# Altium schematic sheet Sheet1.SchDoc
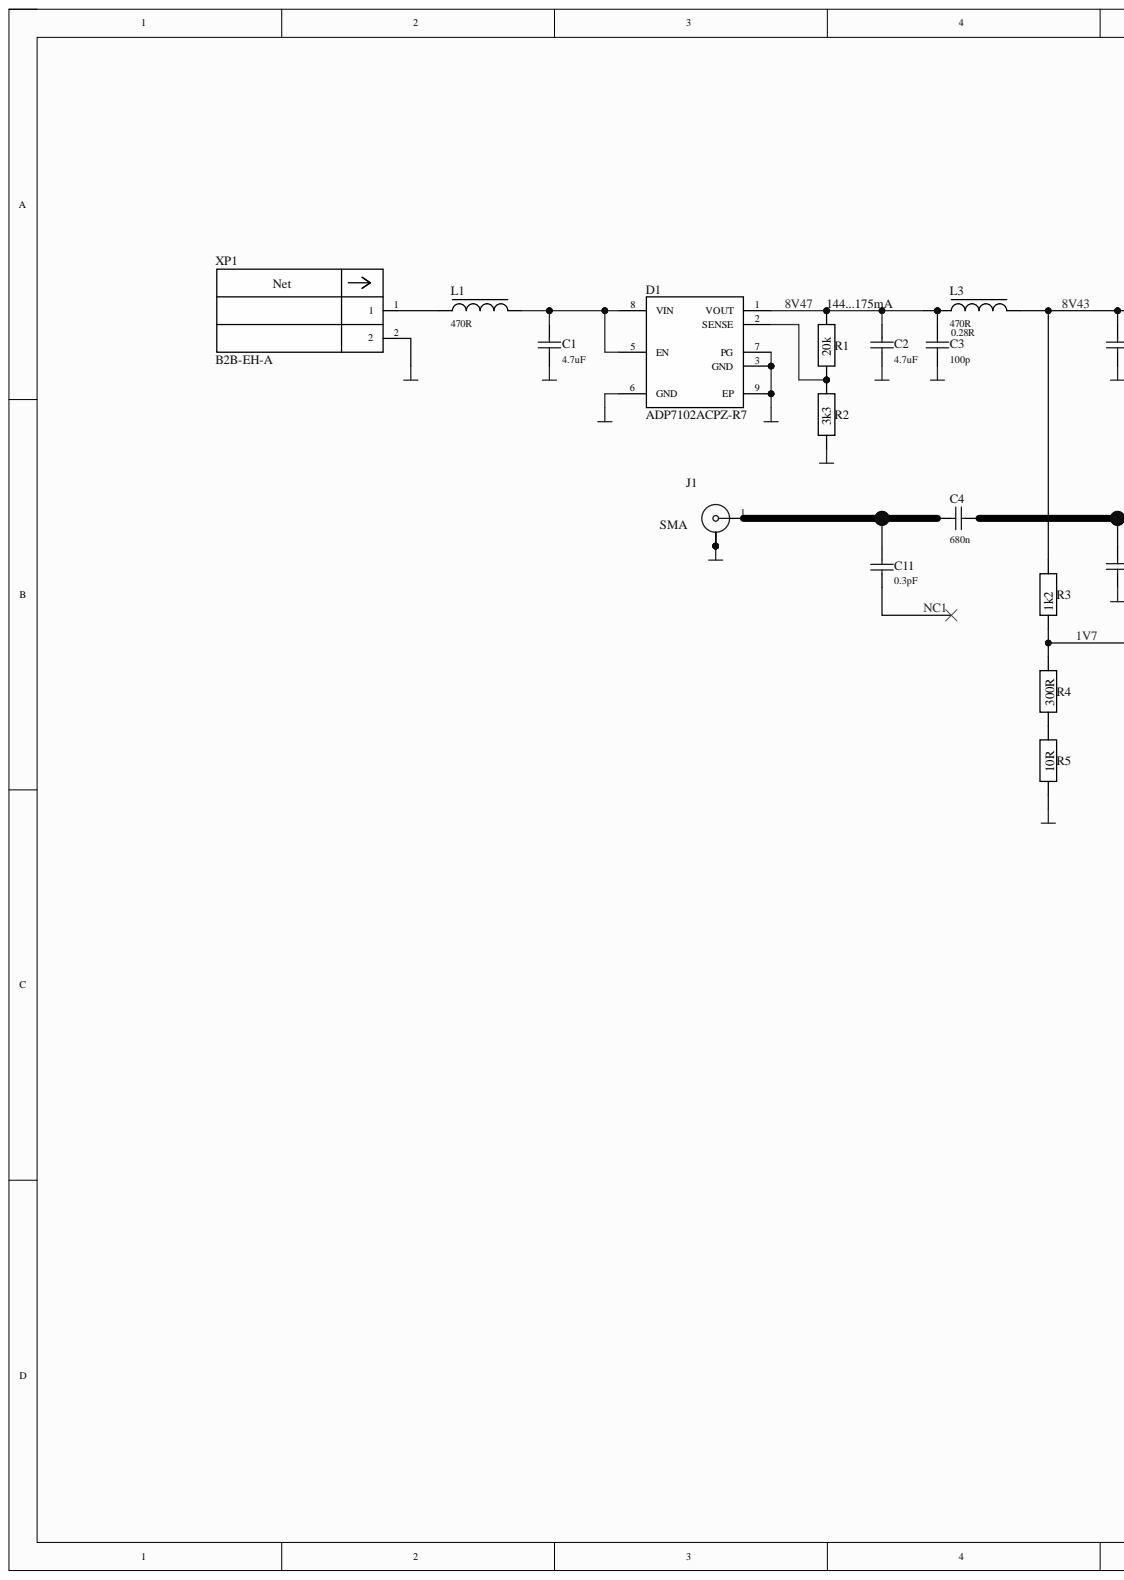
[diagram p0: Sheet1.SchDoc, left]
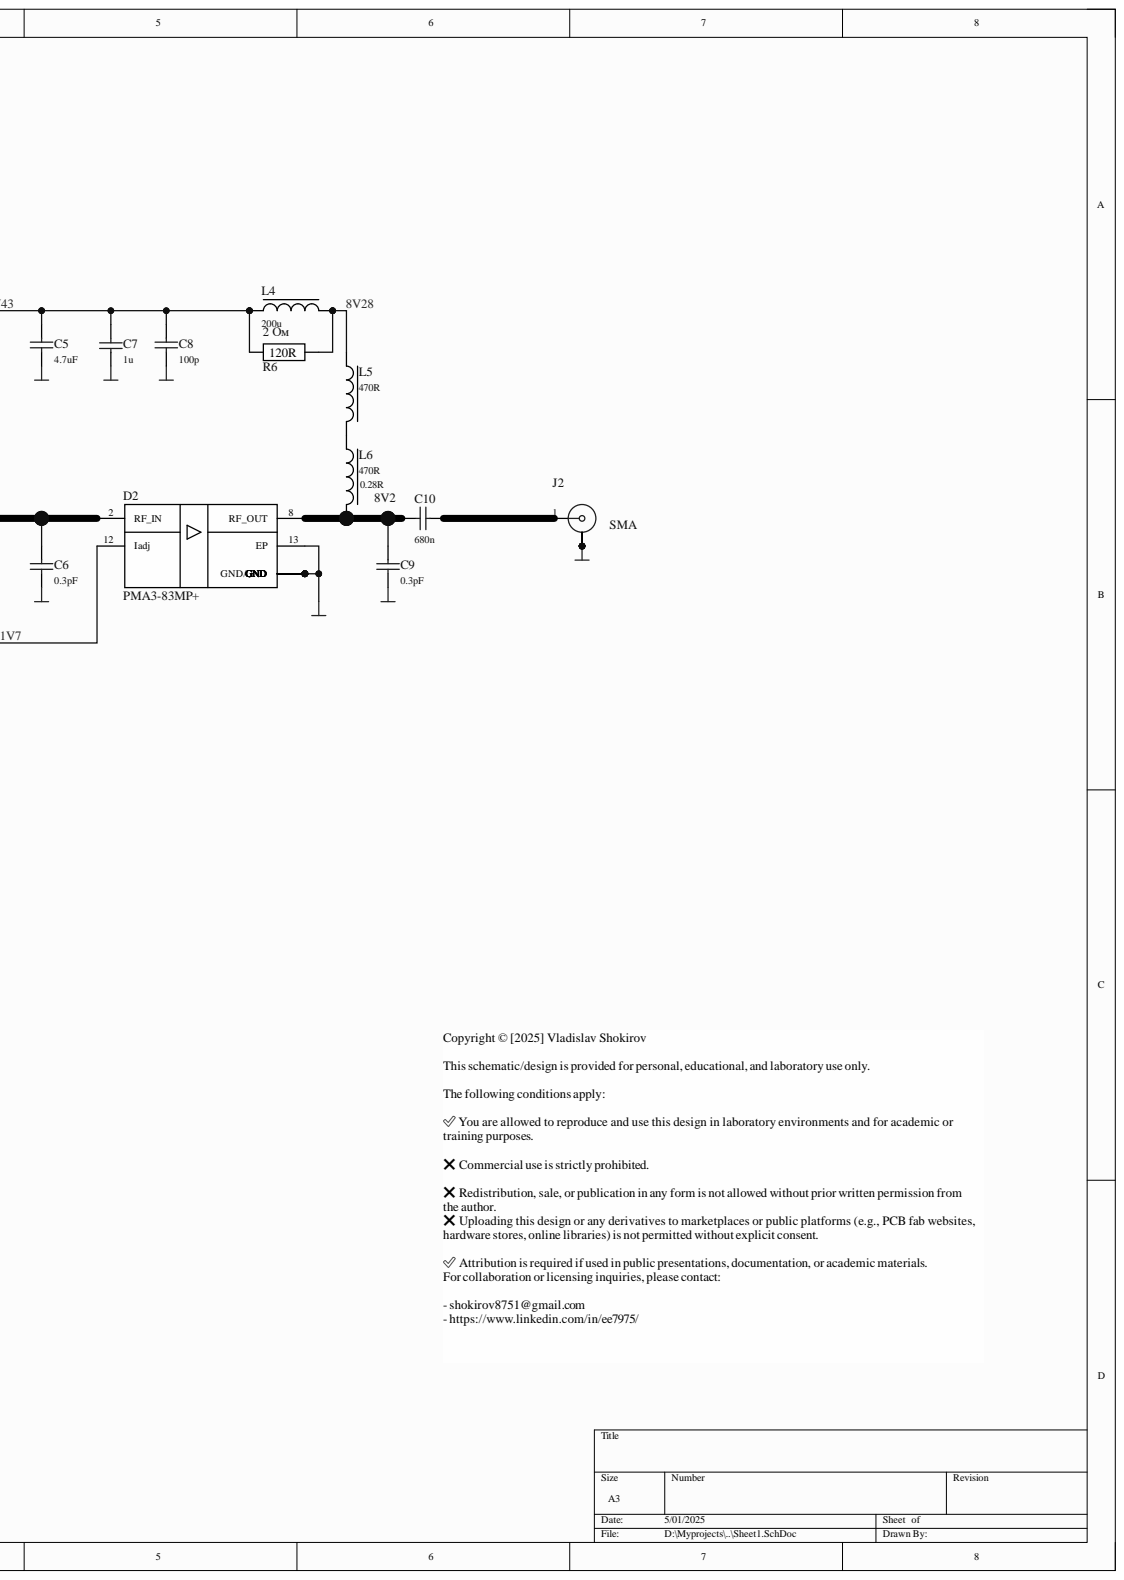
[diagram p1: Sheet1.SchDoc, right]

## Parts
C1  value 4.7uF  [C0603_P]  (hidden comment: CC0603KRX5R8BB475)
C2  value 4.7uF  [C0603_P]  (hidden comment: CC0603KRX5R8BB475)
C3  value 100p  [C0402_P]  (hidden comment: CC0402JRNPO9BN101)
C4  value 680n  [C0402_P]  (hidden comment: GCM155C71A684KE38D)
C5  value 4.7uF  [C0603_P]  (hidden comment: CC0603KRX5R8BB475)
C6  value 0.3pF  [C0402_P]  (hidden comment: CQ0402ARNPO9BNR30)
C7  value 1u  [C0402_P]  (hidden comment: CC0402KRX5R7BB105)
C8  value 100p  [C0402_P]  (hidden comment: CC0402JRNPO9BN101)
C9  value 0.3pF  [C0402_P]  (hidden comment: CQ0402ARNPO9BNR30)
C10  value 680n  [C0402_P]  (hidden comment: GCM155C71A684KE38D)
C11  value 0.3pF  [C0402_P]  (hidden comment: CQ0402ARNPO9BNR30)
D1  ADP7102ACPZ-R7  [TSOT5]
D2  PMA3-83MP+  [QFN12_3X3mm]
J1  SMA8400A2-0000  [SMA8400B]  (value not drawn)
J2  SMA8400A2-0000  [SMA8400B]  (value not drawn)
L1  value 470R  [BLM15_P]  (hidden comment: BLM15EX471SN1D)
L3  value 470R  [BLM15_P]  (hidden comment: BLM15EX471SN1D)
L4  value 200u  [L2020_H2.0mm (5020)]  (hidden comment: SWPA5020S201MT)
L5  value 470R  [BLM15_P]  (hidden comment: BLM15EX471SN1D)
L6  value 470R  DC Resistance (DCR): 0.28R  [BLM15_P]  (hidden comment: BLM15EX471SN1D)
R1  value 20k  [R0402_P]  (hidden comment: RC0402FR-0720KL)
R2  value 3k3  [R0402_P]  (hidden comment: RC0402FR-073K3L)
R3  value 1k2  [R0402_P]  (hidden comment: RC0402FR-071K2L)
R4  value 300R  [R0402_P]  (hidden comment: RC0402FR-07300RL)
R5  value 10R  [R0402_P]  (hidden comment: RC0402FR-0710RP)
R6  value 120R  [R0603_P]  (hidden comment: RC0603FR-07120RL)
XP1  B2B-EH-A  [B2B-EH-A]

## Nets
GND: C1.1 C2.1 C3.1 C5.1 C6.1 C7.2 C8.1 C9.1 D1.3(GND) D1.6(GND) D1.7(PG) D1.9(EP) D2.1(GND/GND) D2.3 D2.4 D2.5 D2.6 D2.7 D2.9 D2.10 D2.11 D2.13(EP) J1.2 J1.3 J1.4 J1.5 J2.2 J2.3 J2.4 J2.5 R2.1 R5.1 XP1.2
1V7: D2.12(Iadj) R3.2 R4.2
8V28: L4.2 L5.2 R6.2
8V43: C5.2 C7.1 C8.2 L3.1 L4.1 R3.1 R6.1
8V47: C2.2 C3.2 D1.1(VOUT) L3.2 R1.2
NC1: C11.2
NetC1_2: C1.2 D1.5(EN) D1.8(VIN) L1.1
NetC4_1: C4.1 J1.1
NetC4_2: C4.2 C6.2 D2.2(RF_IN)
NetC9_2: C9.2 C10.1 D2.8(RF_OUT) L6.1
NetC10_2: C10.2 J2.1
NetD1_2: D1.2(SENSE) R1.1 R2.2
NetL1_2: L1.2 XP1.1
NetL5_1: L5.1 L6.2
NetR4_1: R4.1 R5.2

Not connected: C11 1

## Library data of the parts
C1 C2 C5 = CMP-001-00011-5: CAP CER 0603 4.7u 25V 10% X5R; Case Code (Imperial): 0603; Component Kind: Standard; Dielectric: X5R; Height: 900um; JLCPCB: C326580; Max Operating Temperature: 85°C; Min Operating Temperature: -55°C; Tolerance: 10%; Voltage Rating (DC): 25V; Library Name: CMT EU LTD
C3 C8 = CMP-001-00107-32: CAP CER 0402 100p 50V 5% NP0; Manufacturer: Yageo; Capacitor Type: Ceramic; CODE: 11710; Dielectric: C0G; PECH: 1; ROTATE: 0; Case Code (Imperial): 0402; Component Kind: Standard; JLCPCB: C106200; Max Operating Temperature: 125°C; Min Operating Temperature: -55°C; Mounting Technology: SMD; PACKAGE: C0402; Pin Count: 2; Tolerance: 5%; Voltage: 50V; Library Name: CMT EU LTD
C4 C10 = CMP-001-00015-2: CAP CER 0402 680n 10V 10% X7R; Component Kind: Standard; Height: 700um; JLCPCB: C710661; Max Operating Temperature: 125°C; Min Operating Temperature: -55°C; Tolerance: 10%; Voltage Rating: 10V; Library Name: CMT EU LTD
C6 C9 C11 = CMP-001-00004-4: CAP CER 0402 0.3p 50V COG; Case Code (Imperial): 0402; Component Kind: Standard; JLCPCB: C1870422; Library Name: CMT EU LTD
C7 = CMP-001-00129-20: CAP CER 0402 1u 16V 10% X5R; Manufacturer: Yageo; Capacitor Type: Ceramic; CODE: 11710; PECH: 1; ROTATE: 0; Case Code (Imperial): 0402; Component Kind: Standard; Dielectric: X5R; JLCPCB: C325464; Mounting Technology: SMD; PACKAGE: C0402; Pin Count: 2; Tolerance: 10%; Voltage: 16V; Library Name: CMT EU LTD
D1 = CMP-115-000012-7: LDO, ADJ. Low Noise, 20V, 300mA; CODE: 10500; FIRM: {FIRM}; NOM_NAME: Микросхема-ADP7102ACPZ-5.0-Источник; PECH: 2; ROTATE: 270; STORE_ID: 156796; Value: ADP7102-5.0; Component Kind: Standard; Component Type: Standard; ComponentLink1Description: Link; ComponentLink1URL: https://www.analog.com/media/en/technical-documentation/data-sheets/ADP7102.pdf; Design Item ID: ADP7102; JLCPCB: C659319; PACKAGE: {CP-8-5}; Pin Count: 9; Source: FS5435v6.SCHLIB; Library Name: CMT EU LTD
D2 = CMP-000022-3: Amplifier RF 0.4 to 8 GHz; Manufacture: MiniCircuits; Component Kind: Standard; ComponentLink1Description: DataSheet; ComponentLink1URL: https://www.minicircuits.com/WebStore/dashboard.html?model=PMA3-83MP%2B; JLCPCB: C5445183; Price: 10.29; Library Name: CMT EU LTD
J1 J2 = CMP-002-00079-17: SMA Coaxial Jack Female, PCB Mount, 0,5mm, stright; Component Kind: Standard; ComponentLink1Description: Link; ComponentLink1URL: http://www.100y.com.tw/viewproduct.asp?MNo=56247; ComponentLink2Description: DataSheet1; ComponentLink2URL: http://images.100y.com.tw/pdf_file/09-SMA8400B-0000.pdf; Manufacturer: www.100y.com.tw; Library Name: CMT EU LTD
L1 L3 L5 L6 = CMP-006-00031-16: FERRITE BEAD 0402 470R 25% 950mA (GHz) 0.28R; Manufacturer: Murata; CODE: 11810; PECH: 1; ROTATE: 0; Case Code (Imperial): 0402; Component Kind: Standard; Core Material: Ferrite; Current Rating: 950mA; JLCPCB: C160971; Max Operating Temperature: 125°C; Min Operating Temperature: -55°C; Mounting Technology: SMD; Parameter 1: https://ds.murata.co.jp/simsurfing/blm.html?lcid=en-us&md5=ac9b9d6e4e95d8c9ae5c9757b27ce5df; Tolerance: 25%; Library Name: CMT EU LTD
  L1 L3 L5: DC Resistance (DCR): 0.28R
L4 = CMP-006-00047-6: IND WIRE 5020 200u 20% 0.33A 2Ohm; ESR: 2.0R; Component Kind: Standard; Library Name: CMT EU LTD
R1 = CMP-009-00306-2: RES CER 0402 20k 1% 63mA; Power Rating: 63mW; Voltage Rating: 50V; Case Code (Imperial): 0402; Component Kind: Standard; JLCPCB: C93942; Resistance Tolerance: 1%; Tolerance: 1%; Library Name: CMT EU LTD
R2 = CMP-009-00245-15: RES CER 0402 3.3k  1% 62mW; Manufacturer: YAGEO; CODE: 11650; Max Power Dissipation: 63mW; PECH 1: 1; Power Rating: 62.5mW; Voltage Rating: 50V; Case Code (Imperial): 0402; Component Kind: Standard; Max Operating Temperature: 155°C; Min Operating Temperature: -55°C; Mounting Technology: Surface Mount; PACKAGE: R0402; Tolerance: 1%; Library Name: CMT EU LTD
R3 = CMP-009-00299-3: RES CER 0402 1.2k 1% 63mW; Case Code (Imperial): 0402; Component Kind: Standard; JLCPCB: C138040; Library Name: CMT EU LTD
R4 = CMP-009-00208-41: RES CER 0402 300R 1% 63mW; Manufacturer: YAGEO; CODE: 11650; PECH 1: 1; Power Rating: 62.5mW; ROTATE: 0; Voltage Rating: 50V; Case Code (Imperial): 0402; Component Kind: Standard; JLCPCB: C138010; Max Operating Temperature: 155°C; Max Power Dissipation: 63mW; Min Operating Temperature: -55°C; Mounting Technology: Surface Mount; PACKAGE: R0402; Pin Count: 2; Tolerance: 1%; Library Name: CMT EU LTD
R5 = CMP-009-00300-3: RES CER 0402 10R 1% 63mW; Component Kind: Standard; JLCPCB: C851817; Library Name: CMT EU LTD
R6 = CMP-009-00298-2: RES CER 0603 120R 1% 0.125W; Component Kind: Standard; JLCPCB: C114640; Library Name: CMT EU LTD
XP1 = CMP-002-00088-4: CONN HEADER VERT 2POS 2.5MM; Manufacturer: JST; Component Kind: Standard; JLCPCB: C160262; Max Operating Temperature: 85°C; Min Operating Temperature: -25°C; Mounting Technology: TH; Library Name: CMT EU LTD
